# Altium schematic sheet Analog_Control.SchDoc
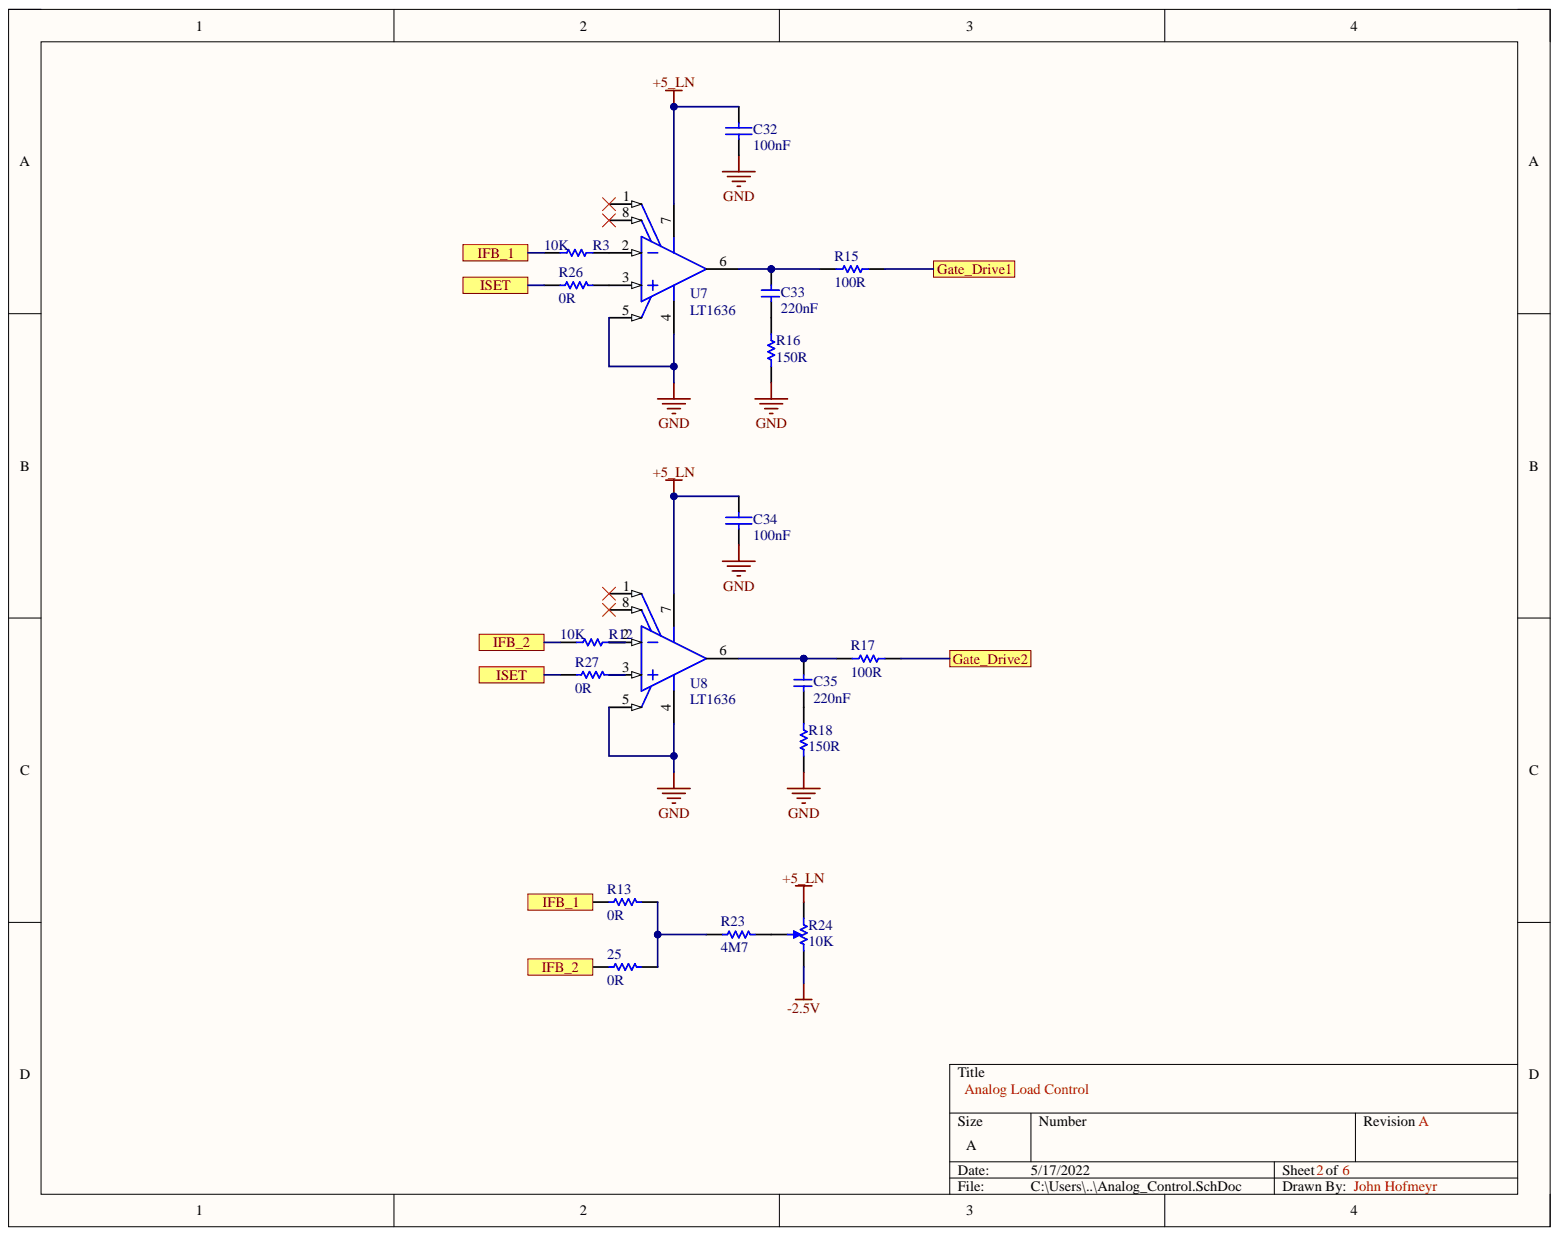
[diagram p0: Analog_Control.SchDoc]

## Parts
25  0R  [R0805]
C32  100nF  [CAPC2013X140X50ML20T25]
C33  220nF  [C0805]
C34  100nF  [CAPC2013X140X50ML20T25]
C35  220nF  [C0805]
R3  10K  [RESC2013X50X40NL20T20]
R12  10K  [RESC2013X50X40NL20T20]
R13  0R  [R0805]
R15  100R  [RESC2013X50X40ML20T20]
R16  150R  [RESC2013X50X40ML20T20]
R17  100R  [RESC2013X50X40ML20T20]
R18  150R  [RESC2013X50X40ML20T20]
R23  4M7  [R0805]
R24  10K  [BOUR-3296W]
R26  0R  [R0805]
R27  0R  [R0805]
U7  LT1636  [LT-MS8-8_M]
U8  LT1636  [LT-MS8-8_M]

## Ports
Gate_Drive1, Gate_Drive2, IFB_1, IFB_2, ISET

## Nets
+5_LN: C32.2 C34.2 R24.1 U7.7 U8.7
-2.5V: R24.3
GND: C32.1 C34.1 R16.1 R18.1 U7.4 U7.5 U8.4 U8.5
Gate_Drive1: R15.2 ; port Gate_Drive1
Gate_Drive2: R17.2 ; port Gate_Drive2
IFB_1: R3.1 R13.1 ; port IFB_1
IFB_2: 25.1 R12.1 ; port IFB_2
ISET: R26.1 R27.1 ; port ISET
Net25_2: 25.2 R13.2 R23.1
NetC33_1: C33.1 R16.2
NetC33_2: C33.2 R15.1 U7.6
NetC35_1: C35.1 R18.2
NetC35_2: C35.2 R17.1 U8.6
NetR3_2: R3.2 U7.2
NetR12_2: R12.2 U8.2
NetR23_2: R23.2 R24.2
NetR26_2: R26.2 U7.3
NetR27_2: R27.2 U8.3

Not connected: U7 1 8; U8 1 8

## Library data of the parts
25 R13 R26 R27 = RMCF0805ZT0R00: STACKPOLE ELECTRONICS - RMCF0805ZT0R00. - RES THKFLM 0805 0 OHM JUMPER 1/8W 200PPM/ C SMD ROHS COMPLIANT: YES; Max Power Dissipation: 125mW; Resistance: 0mR; Case Code (Imperial): 0805; Case Code (Metric): 2012; Case/Package: 0805; Category: Chip SMD Resistors; Component Kind: Standard; ComponentLink1Description: https://datasheet.ciiva.com/15193/getdatasheetpartid-360417-15193658.pdf?src-supplier=Verical; ComponentLink1URL: https://datasheet.ciiva.com/15193/getdatasheetpartid-360417-15193658.pdf?src-supplier=Verical; Contact Plating: Tin,Matte; Depth: 1.25mm; Features: Automotive AEC-Q200; Height: 0.026inch; Lead Free: Lead Free; Length: 2mm; Library Name: MainBoard.SchLib; Manufacturer: Stackpole Electronics; Manufacturer Part Number: RMCF0805ZT0R00; Max Operating Temperature: 155°C; Military Standard: Not; Min Operating Temperature: -55°C; Mount: Surface Mount; Number of Terminations: 2; Packaging: Tape and Reel; Power Rating: 125mW; Pricing 1: 1=0.10000, 10=0.01800, 25=0.01320, 50=0.01020, 100=0.00750, 250=0.00572, 500=0.00458, 1000=0.00337 (USD); Radiation Hardening: No; Resistor Type: Thick Film; RoHS: Yes; Stock 1: 2500609; Supplier 1: Digi-Key; Supplier Part Number 1: RMCF0805ZT0R00CT-ND; Width: 0.049inch
C32 C34 = CMP-2007-04689-1: Manufacturer: AVX Interconnect / Elco; Part Number: 08055D104KAT2A; Component Kind: Standard; Library Name: Altium Content Vault
C33 C35 = C2012X5R1H224M125AA: CAP CER 0.22UF 50V X5R 0805; Manufacturer: TDK; Dielectric Material: Ceramic; Tolerance: 20%; Capacitance: 220nF; Case Code (Imperial): 2012; Case Code (Metric): 5032; Case/Package: 0805; Category: Ceramic Capacitors; Component Kind: Standard; ComponentLink1Description: https://datasheet.ciiva.com/14903/tdkmlcccaprange-14903109.pdf?src-supplier=Digi-Key; ComponentLink1URL: https://datasheet.ciiva.com/14903/tdkmlcccaprange-14903109.pdf?src-supplier=Digi-Key; ComponentLink2Description: https://datasheet.ciiva.com/14903/tdkmlcccaprange-14903112.pdf?src-supplier=Digi-Key; ComponentLink2URL: https://datasheet.ciiva.com/14903/tdkmlcccaprange-14903112.pdf?src-supplier=Digi-Key; Lead Free: Lead Free; Length: 0.079inch; Library Name: DCLoadV2.SchLib; Manufacturer Part Number: C2012X5R1H224M125AA; Max Operating Temperature: 85°C; Min Operating Temperature: -55°C; Mount: Surface Mount; Package Quantity: 2000; Packaging: Tape and Reel; Pricing 1: 1=0.18000, 10=0.12800, 50=0.07240, 100=0.06000, 500=0.04208, 1000=0.03483 (USD); RoHS: Yes; Stock 1: 2526; Supplier 1: Digi-Key; Supplier Part Number 1: 445-14406-1-ND; Thickness: 0.057inch; Voltage Rating: 50V; Voltage Rating (DC): 50V; Width: 0.049inch
R3 R12 = CMP-2001-03215-1: Manufacturer: Susumu; Part Number: RR1220P-103-D; Component Kind: Standard; Library Name: Altium Content Vault
R15 R17 = CMP-2001-03208-1: Manufacturer: Susumu; Part Number: RR1220P-101-D; Component Kind: Standard; Library Name: Altium Content Vault
R16 R18 = CMP-2001-03269-1: Manufacturer: Susumu; Part Number: RR1220P-151-D; Component Kind: Standard; Library Name: Altium Content Vault
R23 = RC0805FR-074M7L: Surface Mount Chip Resistor, Thick Film, RC Series, 4.7 Mohm, 125 mW, 1%, 150 V ;RoHS Compliant: Yes; Manufacturer: Yageo; Max Power Dissipation: 125mW; Resistance: 4.7MR; Temperature Coefficient: 100ppm/°C; Voltage Rating: 150V; Case Code (Imperial): 0805; Case Code (Metric): 2012; Case/Package: 0805; Category: Chip SMD Resistors; Component Kind: Standard; ComponentLink1Description: https://datasheet.ciiva.com/11819/0900766b8106beab-11819858.pdf?src-supplier=RSComponents; ComponentLink1URL: https://datasheet.ciiva.com/11819/0900766b8106beab-11819858.pdf?src-supplier=RSComponents; ComponentLink2Description: https://datasheet.ciiva.com/2459/pyu-r-int-thick-7-2459143.pdf?src-supplier=Digi-Key; ComponentLink2URL: https://datasheet.ciiva.com/2459/pyu-r-int-thick-7-2459143.pdf?src-supplier=Digi-Key; ComponentLink3Description: https://datasheet.ciiva.com/2459/pyu-r-int-thick-7-2459144.pdf?src-supplier=Digi-Key; ComponentLink3URL: https://datasheet.ciiva.com/2459/pyu-r-int-thick-7-2459144.pdf?src-supplier=Digi-Key; ComponentLink4Description: https://datasheet.ciiva.com/12667/rc0805-12667070.pdf?src-supplier=Mouser; ComponentLink4URL: https://datasheet.ciiva.com/12667/rc0805-12667070.pdf?src-supplier=Mouser; ComponentLink5Description: https://datasheet.ciiva.com/7941/444164-7941807.pdf?src-supplier=Element14; ComponentLink5URL: https://datasheet.ciiva.com/7941/444164-7941807.pdf?src-supplier=Element14; ComponentLink6Description: https://datasheet.ciiva.com/2459/pyu-r-int-thick-7-2459142.pdf?src-supplier=Digi-Key; ComponentLink6URL: https://datasheet.ciiva.com/2459/pyu-r-int-thick-7-2459142.pdf?src-supplier=Digi-Key; Depth: 1.25mm; Features: Moisture Resistant; Height: 0.024inch; Lead Free: Lead Free; Length: 2mm; Library Name: DCLoadV2.SchLib; Manufacturer Part Number: RC0805FR-074M7L; Max Operating Temperature: 155°C; Military Standard: Not; Min Operating Temperature: -55°C; Number of Pins: 2; Number of Terminations: 2; Package Quantity: 1; Packaging: Tape and Reel; Power Rating: 125mW; Pricing 1: 1=0.10000, 10=0.03900, 100=0.01570, 1000=0.00705, 2500=0.00612 (USD); REACH SVHC: Unknown; Resistor Type: Thick Film; RoHS: Yes; Stock 1: 130994; Supplier 1: Digi-Key; Supplier Part Number 1: 311-4.70MCRCT-ND; Termination: SMD/SMT; Tolerance: 1%; Voltage Rating (DC): 150V; Width: 0.049inch
R24 = CMP-2000-05582-1: Trimming Potentiometer, 2000 Ohm, -55 to 125 degC, 3-Pin THD, RoHS, Tube; Manufacturer: Bourns; Angle of rotation: 9000°; Curve: linear; Dielectric strength: 900; Power: 500; Resistance: 2; Tolerance: ±10%; Turns: 25; Component Kind: Standard; Datasheet URL: https://datasheet.ciiva.com/17991/287-1114-17991984.pdf; Datasheet Version: 08/2010; Library Name: Altium Content Vault; Manufacturer URL: http://www.bourns.com/; Mounting Technology: ThroughHole; Package Description: 3-Pin Through Hole Device, Body 9.53 x 4.83 mm, Pitch 2.54 mm; Package Reference: 3296W; Series: 3296; Temperature range: -55...+125°C
U7 U8 = CMP-0359-00735-2: Single Channel, Micropower Rail-to-Rail Operational Amplifier, 0.2 MHz Typical GBW, 0.07 V/us Typical SR, 2.6 to 44 V, 8-Pin SOP (MS8-8), Commercial, Pb-Free, Reel; Manufacturer: Linear Technology; PartNumber: LT1636CMS8#TRPBF; Channels (#): 1; GBW (MHz) Typical: 0.2; Is (mA) Typical: 0.042; SR (V/us) Typical: 0.07; Vos (mV) Guaranteed: 0.225; Vos (mV) Typical: 0.05; Vs Max (V): 44; Vs Min (V): 2.6; Component Kind: Standard; ComponentLink1Description: Manufacturer URL; ComponentLink1URL: http://www.linear.com/; ComponentLink2Description: Datasheet; ComponentLink2URL: http://cds.linear.com/docs/en/datasheet/1636fc.pdf; ComponentLink3Description: Package Specification; ComponentLink3URL: http://cds.linear.com/docs/en/packaging/05081610_G_SO8.pdf; DatasheetVersion: Rev. C; Library Name: Altium Content Vault; Linear Technology Part Number: LT1636; Mounting Technology: Surface Mount; PackageDescription: 8-Lead Plastic Small Outline, Body 3.9 x 4.9 mm, Pitch 1.27 mm; PackageReference: LT-MS8-8; PackageVersion: Rev G; Packing: Reel; RevisionNote: URLs updated; RoHS: Pb-Free; Type: VFB
